# Altium schematic sheet bug7seg.SchDoc
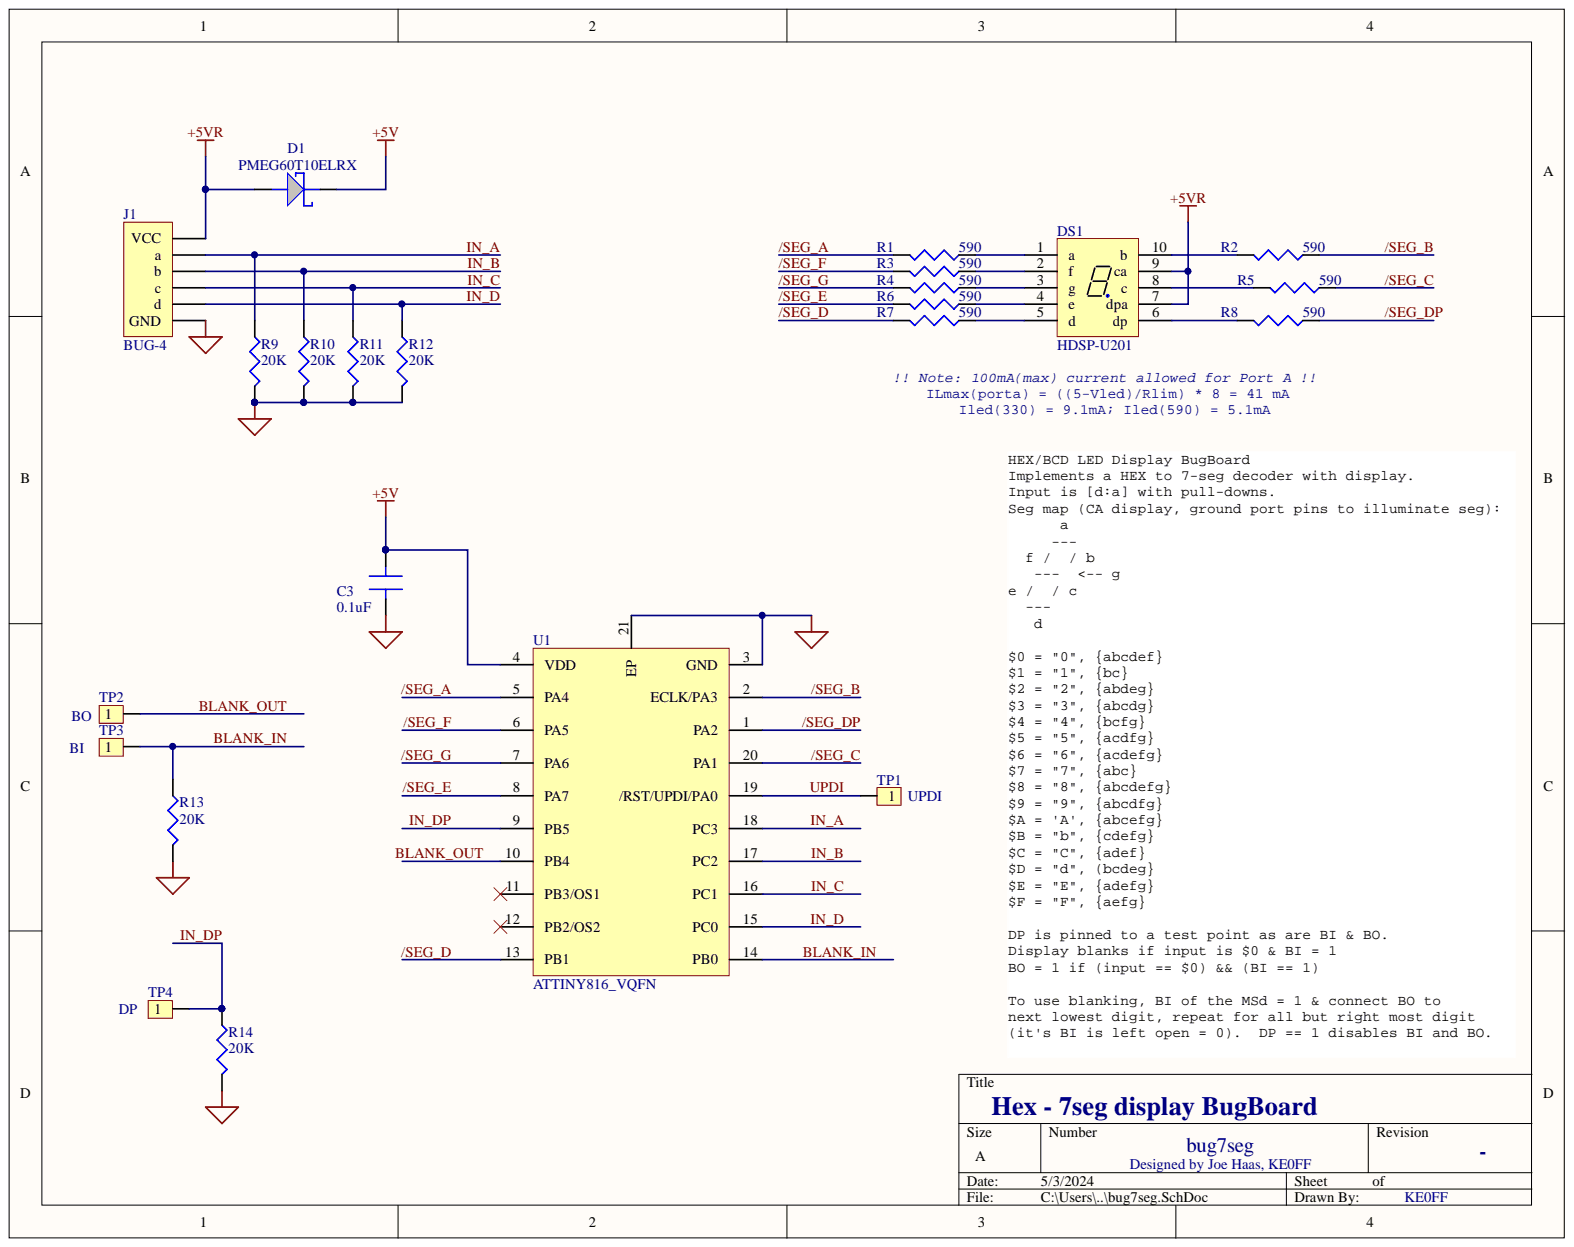
[diagram p0: bug7seg.SchDoc]

## Parts
C3  0.1uF  [0402]
D1  PMEG60T10ELRX  [SOD123]
DS1  HDSP-U201  [HDSP-U201]
J1  BUG-4  [BUG-4]
R1  590  [0402]
R2  590  [0402]
R3  590  [0402]
R4  590  [0402]
R5  590  [0402]
R6  590  [0402]
R7  590  [0402]
R8  590  [0402]
R9  20K  [0402]
R10  20K  [0402]
R11  20K  [0402]
R12  20K  [0402]
R13  20K  [0402]
R14  20K  [0402]
TP1  UPDI  [TP-SMD-20x50-SQ]  (no BOM)
TP2  BO  [TP-HOLE-40]  (no BOM)
TP3  BI  [TP-HOLE-40]  (no BOM)
TP4  DP  [TP-HOLE-40]  (no BOM)
U1  ATTINY816_VQFN  [VQFN20_3X3_SM]

## Nets
+5V: C3.1 D1.1 U1.4(VDD)
+5VR: D1.2 DS1.7(dpa) DS1.9(ca) J1.6(VCC)
GND: C3.2 J1.5(GND) R9.1 R10.1 R11.1 R12.1 R13.1 R14.1 U1.3(GND) U1.21(EP)
/SEG_A: R1.1 U1.5(PA4)
/SEG_B: R2.2 U1.2(ECLK/PA3)
/SEG_C: R5.2 U1.20(PA1)
/SEG_D: R7.1 U1.13(PB1)
/SEG_DP: R8.2 U1.1(PA2)
/SEG_E: R6.1 U1.8(PA7)
/SEG_F: R3.1 U1.6(PA5)
/SEG_G: R4.1 U1.7(PA6)
BLANK_IN: R13.2 TP3.1 U1.14(PB0)
BLANK_OUT: TP2.1 U1.10(PB4)
IN_A: J1.1(a) R9.2 U1.18(PC3)
IN_B: J1.2(b) R10.2 U1.17(PC2)
IN_C: J1.3(c) R11.2 U1.16(PC1)
IN_D: J1.4(d) R12.2 U1.15(PC0)
IN_DP: R14.2 TP4.1 U1.9(PB5)
UPDI: TP1.1 U1.19(/RST/UPDI/PA0)
NetDS1_1: DS1.1(a) R1.2
NetDS1_2: DS1.2(f) R3.2
NetDS1_3: DS1.3(g) R4.2
NetDS1_4: DS1.4(e) R6.2
NetDS1_5: DS1.5(d) R7.2
NetDS1_6: DS1.6(dp) R8.1
NetDS1_8: DS1.8(c) R5.1
NetDS1_10: DS1.10(b) R2.1

Not connected: U1 11(PB3/OS1) 12(PB2/OS2)

## Library data of the parts
C3 = CAP CER: CAP, CER, 0402, 0.1uF, 50V; MFR: AVX; MPN: 04025C104KAT2A; Component Kind: Standard
D1 = DIODE_SCHOTTKY_SOD123: DIODE, SHTKY; MFR: Nexperia; ALT-MPN: -; Component Kind: Standard; DNP: -; MPN: PMEG60T10ELRX; NOTES: -; NOTES2: -; PRI-MPN: -; Library Name: C:\Users\ke0ff\Documents\Altium\GalaxyLibs-jmh_1.08\Galaxy PCB-jem-1.08.PcbLib
DS1 = 7SEG_LED_HSDP-U201: LED, 7-SEG, CC; MFR: Broadcom; ALT-MPN: -; Component Kind: Standard; DNP: -; MPN: HDSP-U201; NOTES: -; NOTES2: -; PRI-MPN: -; Library Name: Galaxy SCH-jem-1.08.SchLib
J1 = BUG-4_PWR: CON, BUG-BD, 4pos+pwr; NOTES: Uses hand-cut wire pins; ALT-MPN: -; Component Kind: Standard; DNP: -; MFR: N/A; MPN: N/A; NOTES2: -; PRI-MPN: -; Library Name: Galaxy SCH-jem-1.08.SchLib
R1-R8 = R0805: RES, CHP, 0402, 1%, 590; MFR: Yageo; MPN: RC0402FR-07590RL; Component Kind: Standard; Library Name: C:\Users\ke0ff\Documents\Altium\GalaxyLibs-jmh_1.08\Galaxy PCB-jem-1.08.PcbLib
R9-R14 = R0805: RES, CHP, 0402, 1%, 20K; MFR: Yageo; MPN: RC0402FR-0720KL; Component Kind: Standard; Library Name: C:\Users\ke0ff\Documents\Altium\GalaxyLibs-jmh_1.08\Galaxy PCB-jem-1.08.PcbLib
TP1-TP4 = TP: MECH, TEST POINT; ALT-MPN: -; DNP: -; MFR: -; MPN: -; NOTES: -; NOTES2: -; PRI-MPN: -; Library Name: Galaxy SCH-jem-1.08.SchLib
U1 = ATTINY816_VQFN: IC, MCU, SFF, 8b, 8Kb, 20MHz; MFR: Microchip; MPN: ATTINY816-MN; ALT-MPN: -; Component Kind: Standard; DNP: -; NOTES: -; NOTES2: -; PRI-MPN: -; Library Name: Galaxy SCH-jem-1.08.SchLib
